# Altium schematic sheet Fonte.SchDoc(Fonte)
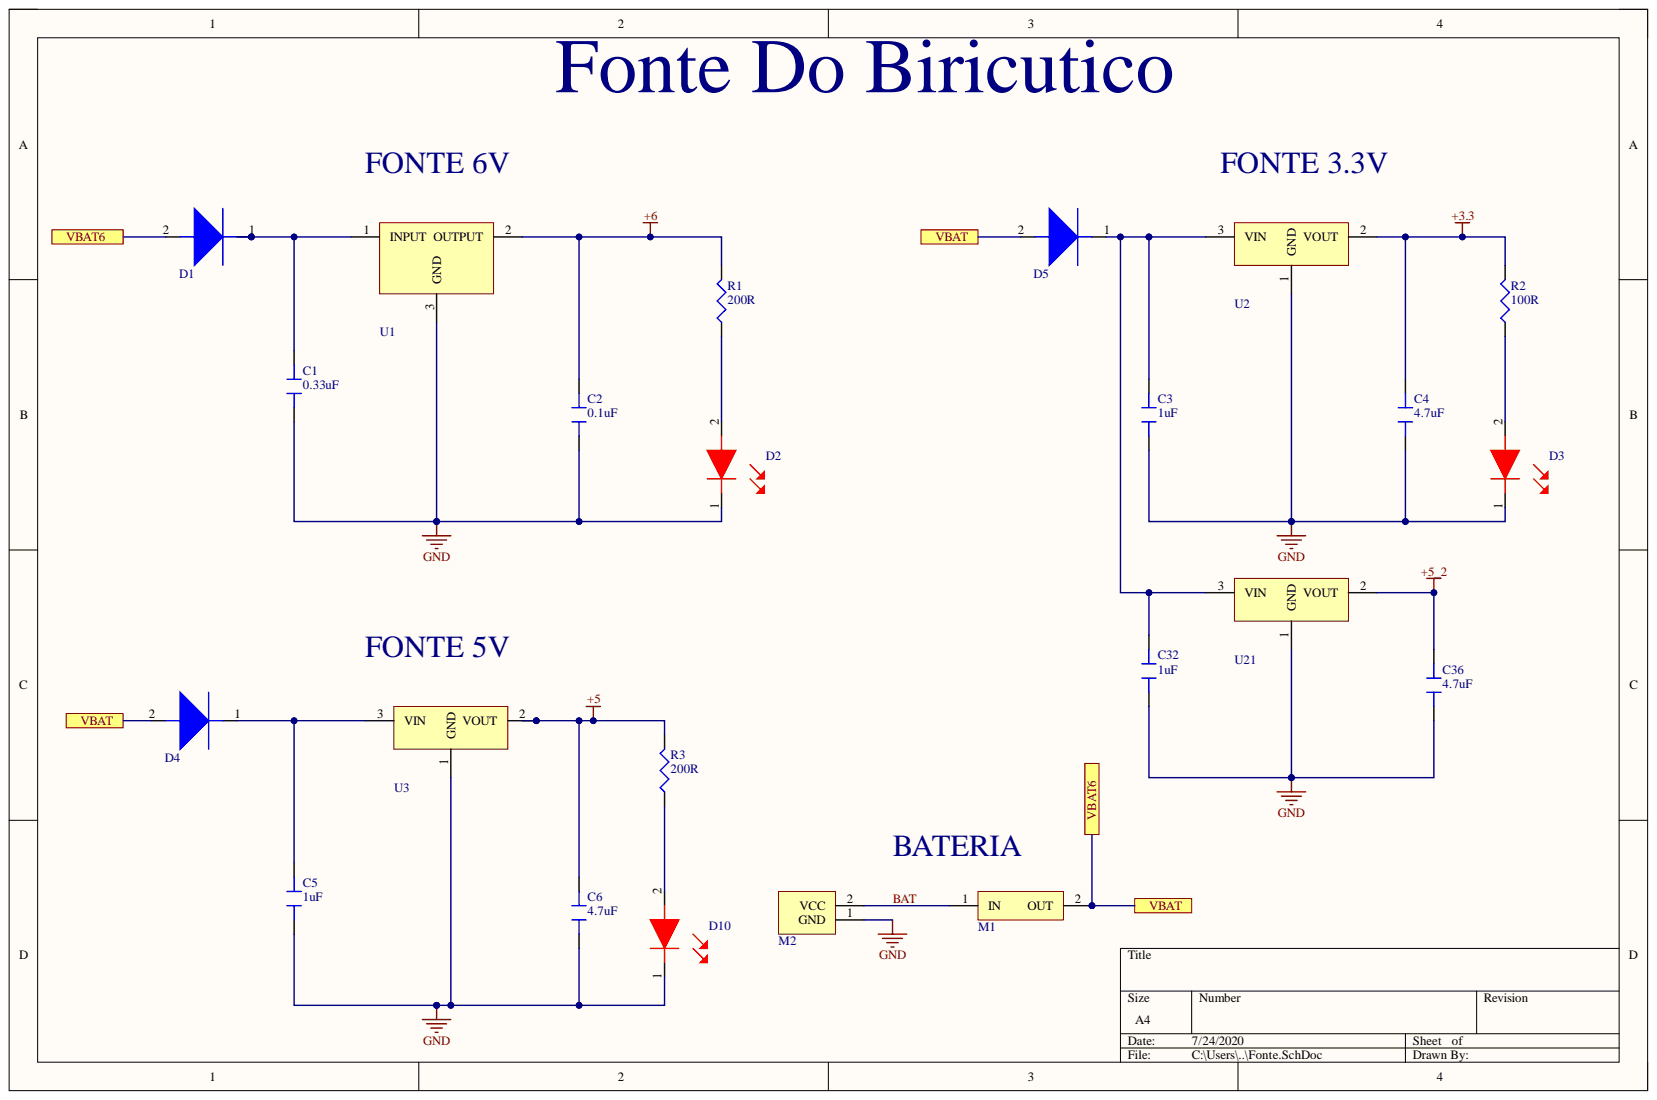
[diagram p0: Fonte.SchDoc]

## Parts
C1  0.33uF  [CAPACITOR 0805]
C2  0.1uF  [CAPACITOR 0805]
C3  1uF  [CAPACITOR 0805]
C4  4.7uF  [CAPACITOR 0805]
C5  1uF  [CAPACITOR 0805]
C6  4.7uF  [CAPACITOR 0805]
C32  1uF  [CAPACITOR 0805]
C36  4.7uF  [CAPACITOR 0805]
D1  Schottky Diode  [SODFL3516X85N]  (value not drawn)
D2  Standard LEDs - SMD  [Standard LEDs - SMD]  (value not drawn)
D3  Standard LEDs - SMD  [Standard LEDs - SMD]  (value not drawn)
D4  Schottky Diode  [SODFL3516X85N]  (value not drawn)
D5  Schottky Diode  [SODFL3516X85N]  (value not drawn)
D10  Standard LEDs - SMD  [Standard LEDs - SMD]  (value not drawn)
M1  Slide Switches  [Power_Switch]  (value not drawn)
M2  Headers (1x2)  [BATT]  (value not drawn)
R1  200R  [6-0805_M]
R2  100R  [0603]
R3  200R  [6-0805_M]
U1  Voltage Regulators 6V  [Voltage Regulators 6V]  (value not drawn)
U2  Voltage Regulators 3.3V  [SOT230P700X180-4N]  (value not drawn)
U3  Voltage Regulators 5V  [SOT230P700X180-4N]  (value not drawn)
U21  Voltage Regulators 5V  [SOT230P700X180-4N]  (value not drawn)

## Ports
VBAT, VBAT6

## Nets
+3.3: C4.2 R2.2 U2.2
+5: C6.2 R3.2 U3.2
+5_2: C36.2 U21.2
+6: C2.1 R1.2 U1.2
GND: C1.1 C2.2 C3.1 C4.1 C5.1 C6.1 C32.1 C36.1 D2.1 D3.1 D10.1 M2.1 U1.3 U2.1 U3.1 U21.1
BAT: M1.1 M2.2
VBAT: D1.2 D4.2 D5.2 M1.2 ; port VBAT, port VBAT6
NetC1_2: C1.2 D1.1 U1.1
NetC3_2: C3.2 C32.2 D5.1 U2.3 U21.3
NetC5_2: C5.2 D4.1 U3.3
NetD2_2: D2.2 R1.1
NetD3_2: D3.2 R2.1
NetD10_2: D10.2 R3.1

## Library data of the parts
C1 = Capacitor 0.33uF 0805: Multilayer Ceramic Capacitors MLCC - SMD/SMT 0805 0.33uF 16volts X7R 5%; Manufacturer: Vishay Vitramon; Manufacturer Part Number: VJ0805Y334JXJRW1BC; Capacitance: 330nF; Dielectric Material: Tantalum; Voltage Rating (DC): 16V; Case Code (Imperial): 0805; Case Code (Metric): 2012; Case/Package: 0805; Category: Multilayer Ceramic Capacitors MLCC - SMD/SMT; Component Kind: Standard; ComponentLink1Description: http://www.mouser.com/ds/2/427/vjw1bcbascomseries-223529.pdf?src-supplier=Mouser; ComponentLink1URL: http://www.mouser.com/ds/2/427/vjw1bcbascomseries-223529.pdf?src-supplier=Mouser; ComponentLink2Description: http://www.vishay.com/docs/28548/vjw1bcbascomseries.pdf?src-supplier=Avnet; ComponentLink2URL: http://www.vishay.com/docs/28548/vjw1bcbascomseries.pdf?src-supplier=Avnet; Depth: 1.25mm; Dielectric: X7R; Height: 1.45mm; Lead Free: Lead Free; Length: 2mm; Max Operating Temperature: 125°C; Min Operating Temperature: -55°C; Mount: Surface Mount; Package Quantity: 10000; Packaging: Tape and Reel; Pricing 1: 1=0.52, 10=0.52, 50=0.424, 100=0.308, 1000=0.135, 10000=0.075 (USD); Stock 1: 9308; Supplier 1: Mouser; Supplier Part Number 1: 77-VJ0805Y334JXJRBC; Termination: SMD/SMT; Tolerance: 5%; Weight: 0.000194oz; Width: 1.25mm; Library Name: BiricuticoBonito.SchLib
C2 = Capacitor  0.1uF 0805: Multilayer Ceramic Capacitors MLCC - SMD/SMT .1uF 50volts 10%; Manufacturer: Vishay Vitramon; Manufacturer Part Number: VJ0805Y104KXAAT; Capacitance: 100nF; Dielectric: X7R; Voltage: 50V; Case Code (Imperial): 0805; Case Code (Metric): 2012; Case/Package: 0805; Category: Multilayer Ceramic Capacitors MLCC - SMD/SMT; Component Kind: Standard; ComponentLink1Description: http://www.mouser.com/ds/2/427/vjcommercialseries-222796.pdf?src-supplier=Mouser; ComponentLink1URL: http://www.mouser.com/ds/2/427/vjcommercialseries-222796.pdf?src-supplier=Mouser; ComponentLink2Description: http://www.vishay.com/docs/45199/vjcommercialseries.pdf?src-supplier=Digi-Key; ComponentLink2URL: http://www.vishay.com/docs/45199/vjcommercialseries.pdf?src-supplier=Digi-Key; ComponentLink3Description: http://www.vishay.com/docs/45199/vjcommercialseries.pdf?src-supplier=Future+Electronics; ComponentLink3URL: http://www.vishay.com/docs/45199/vjcommercialseries.pdf?src-supplier=Future+Electronics; Depth: 1.25mm; Height: 1.45mm; Lead Free: Lead Free; Length: 2mm; Max Operating Temperature: 125°C; Min Operating Temperature: -55°C; Mount: Surface Mount; Package Quantity: 1; Packaging: Tape and Reel; Pricing 1: 1=0.2, 10=0.2, 50=0.162, 100=0.108, 500=0.095, 1000=0.084, 3000=0.072, 6000=0.069, 9000=0.065, 10000=0.065 (USD); Radiation Hardening: No; REACH SVHC: Unknown; Stock 1: 207342; Supplier 1: Mouser; Supplier Part Number 1: 77-VJ0805Y104KXAAT; Termination: SMD/SMT; Thickness: 0.057inch; Tolerance: 10%; Voltage Rating: 50V; Voltage Rating (DC): 50V; Weight: 0.000194oz; Width: 0.049inch; Library Name: BiricuticoBonito.SchLib
C3 C5 C32 = Capacitor 1uF 0805: Cap Ceramic 1uF 25V X7R 10% SMD 0805 125C Blister T/R; Manufacturer: Vishay; Manufacturer Part Number: VJ0805Y105KXXTW1BC; Dielectric Material: Tantalum; Capacitance: 1uF; Case/Package: 0805; Category: Multilayer Ceramic Capacitors MLCC - SMD/SMT; Component Kind: Standard; ComponentLink1Description: http://www.vishay.com/docs/28548/vjw1bcbascomseries.pdf?src-supplier=Avnet+Europe; ComponentLink1URL: http://www.vishay.com/docs/28548/vjw1bcbascomseries.pdf?src-supplier=Avnet+Europe; Depth: 1.25mm; Dielectric: X7R; Height: 1.25mm; Lead Free: Lead Free; Length: 2mm; Max Operating Temperature: 125°C; Min Operating Temperature: -55°C; Mount: Surface Mount; Packaging: Tape and Reel; Pricing 1: 1=0.11, 10=0.11, 50=0.11, 100=0.055, 1000=0.038, 10000=0.038 (USD); Radiation Hardening: No; Stock 1: 40011; Supplier 1: Mouser; Supplier Part Number 1: 77-VJ805Y105KXXTW1BC; Termination: Wraparound; Tolerance: 10%; Voltage Rating (DC): 25V; Library Name: BiricuticoBonito.SchLib
C4 C6 C36 = Capacitor 4.7uF 0805: MURATA - GRM21BR61H475KE51L - CAP, 4.7µF, 50V, 10%, X5R, 0805; Material: Ceramic; Capacitance: 4.7uF; Case Code (Imperial): 0805; Case Code (Metric): 2012; Case/Package: 0805; Category: Multilayer Ceramic Capacitors MLCC - SMD/SMT; Component Kind: Standard; ComponentLink1Description: http://components.arrow.com/part/dataview/61084395S454511N2606?src-supplier=Arrow; ComponentLink1URL: http://components.arrow.com/part/dataview/61084395S454511N2606?src-supplier=Arrow; ComponentLink2Description: http://components.arrow.com/part/dataview/0323_07281654?src-supplier=Arrow+Europe; ComponentLink2URL: http://components.arrow.com/part/dataview/0323_07281654?src-supplier=Arrow+Europe; ComponentLink3Description: https://search.murata.co.jp/Ceramy/image/img/A01X/G101/ENG/GRM21BR61H475KE51-01.pdf?src-supplier=Digi-Key; ComponentLink3URL: https://search.murata.co.jp/Ceramy/image/img/A01X/G101/ENG/GRM21BR61H475KE51-01.pdf?src-supplier=Digi-Key; ComponentLink4Description: http://search.murata.co.jp/Ceramy/image/img/A01X/G101/ENG/GRM21BR61H475KE51-01.pdf?src-supplier=Digi-Key; ComponentLink4URL: http://search.murata.co.jp/Ceramy/image/img/A01X/G101/ENG/GRM21BR61H475KE51-01.pdf?src-supplier=Digi-Key; ComponentLink5Description: http://www.farnell.com/datasheets/2079186.pdf?src-supplier=Farnell; ComponentLink5URL: http://www.farnell.com/datasheets/2079186.pdf?src-supplier=Farnell; ComponentLink6Description: http://www.farnell.com/datasheets/2079186.pdf?src-supplier=Element14; ComponentLink6URL: http://www.farnell.com/datasheets/2079186.pdf?src-supplier=Element14; ComponentLink7Description: http://www.farnell.com/datasheets/2079186.pdf?src-supplier=Newark; ComponentLink7URL: http://www.farnell.com/datasheets/2079186.pdf?src-supplier=Newark; Depth: 1.25mm; Dielectric: X5R; Height: 1.25mm; Lead Free: Lead Free; Length: 2mm; Manufacturer: Murata; Manufacturer Part Number: GRM21BR61H475KE51L; Max Operating Temperature: 85°C; Min Operating Temperature: -55°C; Mount: Surface Mount; Packaging: Tape and Reel; Pricing 1: 1=0.37, 10=0.256, 50=0.256, 100=0.153, 500=0.115, 1000=0.097, 3000=0.08, 6000=0.075, 9000=0.071, 10000=0.071 (USD); REACH SVHC: No SVHC; Stock 1: 243744; Supplier 1: Mouser; Supplier Part Number 1: 81-GRM21BR61H475KE1L; Thickness: 0.055inch; Tolerance: 10%; Voltage Rating: 50V; Voltage Rating (DC): 50V; Width: 0.049inch; Library Name: BiricuticoBonito.SchLib
D1 D4 D5 = Schottky Diode: DIODE SCHOTTKY 40V 3A MINI2; Manufacturer: Panasonic; Manufacturer Part Number: DB2W40300L; Element Configuration: Single; Max Forward Surge Current (Ifsm): 30A; Max Reverse Leakage Current: 250uA; Recovery Time: 15ns; Average Rectified Current: 3A; Category: Schottky Diodes & Rectifiers; Component Kind: Standard; ComponentLink1Description: http://www.mouser.com/ds/2/315/DB2W403_BED-276390.pdf?src-supplier=Mouser; ComponentLink1URL: http://www.mouser.com/ds/2/315/DB2W403_BED-276390.pdf?src-supplier=Mouser; Forward Current: 3A; Height: 0.8mm; Lead Free: Lead Free; Length: 2.6mm; Max Operating Temperature: 150°C; Max Repetitive Reverse Voltage (Vrrm): 40V; Max Reverse Voltage (DC): 40V; Max Surge Current: 30A; Min Operating Temperature: -55°C; Mount: Surface Mount; Number of Pins: 2; Package Quantity: 3000; Packaging: Tape and Reel; Peak Reverse Current: 250uA; Pricing 1: 1=0.6, 10=0.421, 50=0.421, 100=0.277, 500=0.164, 1000=0.126, 3000=0.104, 9000=0.095, 10000=0.095, 24000=0.089, 45000=0.08 (USD); Reverse Recovery Time: 15ns; Stock 1: 145800; Supplier 1: Mouser; Supplier Part Number 1: 667-DB2W40300L; Width: 1.6mm; Library Name: BiricuticoBonito.SchLib
D2 D3 D10 = Standard LEDs - SMD: WURTH ELEKTRONIK - 150060RS75000 - LED, 0603, RED, 250MCD, 625NM; Manufacturer: Wurth Electronics; Forward Current: 30mA; Forward Voltage: 2V; Lens Style: Clear,Rectangular; Test Current: 20mA; Viewing Angle: 140°; Case Code (Imperial): 0603; Case Code (Metric): 1608; Case/Package: 0603; Category: Standard LEDs - SMD; Color: Red; Component Kind: Standard; ComponentLink1Description: https://katalog.we-online.de/led/datasheet/150060RS75000.pdf?src-supplier=Digi-Key; ComponentLink1URL: https://katalog.we-online.de/led/datasheet/150060RS75000.pdf?src-supplier=Digi-Key; ComponentLink2Description: http://www.produktinfo.conrad.com/datenblaetter/750000-774999/759428-da-01-en-CHIPLED_0603_W_KL_ROT_150060RS75000.pdf?src-supplier=Conrad; ComponentLink2URL: http://www.produktinfo.conrad.com/datenblaetter/750000-774999/759428-da-01-en-CHIPLED_0603_W_KL_ROT_150060RS75000.pdf?src-supplier=Conrad; Dominant Wavelength: 625nm; Height: 0.7mm; Length: 1.6mm; Luminous Intensity: 250mcd; Manufacturer Part Number: 150060RS75000; Max Operating Temperature: 85°C; Min Operating Temperature: -40°C; Mount: Surface Mount; Package Quantity: 1; Packaging: Cut Tape; Pricing 1: 1=0.14, 10=0.138, 50=0.129, 100=0.11, 1000=0.095, 10000=0.095 (USD); REACH SVHC: Unknown; Stock 1: 77279; Supplier 1: Mouser; Supplier Part Number 1: 710-150060RS75000; Wavelength: 625nm; Width: 0.8mm; Library Name: BiricuticoBonito.SchLib
M1 = Slide Switches: 46202LRX-; Manufacturer: Switchcraft; Actuator Length: 8.74mm; Actuator Material: Thermoplastic; Actuator Type: Standard; Contact Current Rating: 3A; Contact Style: Non-Shorting; Max Voltage Rating (AC): 125V; Switch Function: On-Off; Switch Travel: 4.78mm; Throw Configuration: SPST; Category: Slide Switches; Component Kind: Standard; ComponentLink1Description: https://www.alliedelec.com/m/d/b871a11ddd3a58540a403f8c1668b2ef.pdf?src-supplier=Allied+Electronics; ComponentLink1URL: https://www.alliedelec.com/m/d/b871a11ddd3a58540a403f8c1668b2ef.pdf?src-supplier=Allied+Electronics; ComponentLink2Description: http://uk.rs-online.com/webdocs/141a/0900766b8141acc7.pdf?src-supplier=RSComponents; ComponentLink2URL: http://uk.rs-online.com/webdocs/141a/0900766b8141acc7.pdf?src-supplier=RSComponents; ComponentLink3Description: http://www.switchcraft.com/Drawings/46200LRX_SERIES_CD.pdf?src-supplier=Digi-Key; ComponentLink3URL: http://www.switchcraft.com/Drawings/46200LRX_SERIES_CD.pdf?src-supplier=Digi-Key; ComponentLink4Description: http://www.switchcraft.com/Drawings/46200LRX%20SERIES_CD.pdf?src-supplier=Mouser; ComponentLink4URL: http://www.switchcraft.com/Drawings/46200LRX%20SERIES_CD.pdf?src-supplier=Mouser; ComponentLink5Description: http://www.farnell.com/datasheets/814601.pdf?src-supplier=Farnell; ComponentLink5URL: http://www.farnell.com/datasheets/814601.pdf?src-supplier=Farnell; ComponentLink6Description: http://components.arrow.com/part/dataview/42506918S9181833N6102?src-supplier=Arrow; ComponentLink6URL: http://components.arrow.com/part/dataview/42506918S9181833N6102?src-supplier=Arrow; ComponentLink7Description: http://www.farnell.com/datasheets/814601.pdf?src-supplier=Element14; ComponentLink7URL: http://www.farnell.com/datasheets/814601.pdf?src-supplier=Element14; Contact Material: Copper Alloy,Brass; Contact Plating: Silver; Current Rating: 3A; Depth: 13.46mm; Housing Material: Steel; Lead Free: Lead Free; Length: 35.05mm; Manufacturer Part Number: 46202LRX; Max Current Rating: 3A; Max Operating Temperature: 70°C; Max Voltage Rating (DC): 125V; Min Operating Temperature: -20°C; Mount: Panel; Orientation: Vertical; Package Quantity: 1; Packaging: Bulk; Pricing 1: 1=1.31, 10=1.27, 25=1.15, 50=1.02, 100=0.897, 1000=0.897, 10000=0.897 (USD); REACH SVHC: Unknown; Stock 1: 374; Supplier 1: Mouser; Supplier Part Number 1: 502-46202LRX; Termination: Solder; Voltage Rating: 125V; Voltage Rating (AC): 125V; Voltage Rating (DC): 125V; Width: 13.5mm; Library Name: BiricuticoBonito.SchLib
M2 = Headers (1x2): Headers & Wire Housings HDR STRT SINGLE 2P; Manufacturer: Molex; Manufacturer Part Number: 90136-1202; Contact Resistance: 20mR; Current Rating: 3A; Gender: Male; Glow Wire Compliant: Non-compliant; Insulation Resistance: 5GR; Max Voltage Rating (DC): 350.35kV; Number of Circuits: 2; Pitch: 2.54mm; Voltage Rating: 350V; Category: Headers & Wire Housings; Color: Black; Component Kind: Standard; ComponentLink1Description: http://www.molex.com/webdocs/datasheets/pdf/en-us/0901361202_PCB_HEADERS.pdf?src-supplier=Avnet+Europe; ComponentLink1URL: http://www.molex.com/webdocs/datasheets/pdf/en-us/0901361202_PCB_HEADERS.pdf?src-supplier=Avnet+Europe; ComponentLink2Description: http://www.mouser.com/ds/2/276/0901361202_PCB_HEADERS-176812.pdf?src-supplier=Mouser; ComponentLink2URL: http://www.mouser.com/ds/2/276/0901361202_PCB_HEADERS-176812.pdf?src-supplier=Mouser; ComponentLink3Description: http://www.molex.com/webdocs/datasheets/pdf/en-us/0901361202_PCB_HEADERS.pdf?src-supplier=Avnet; ComponentLink3URL: http://www.molex.com/webdocs/datasheets/pdf/en-us/0901361202_PCB_HEADERS.pdf?src-supplier=Avnet; ComponentLink4Description: https://www.distrelec.biz/Web/Downloads/_d/wg/cgrid_III_90136_eng_dwg.pdf?mime=application%2Fpdf&src-supplier=Distrelec; ComponentLink4URL: https://www.distrelec.biz/Web/Downloads/_d/wg/cgrid_III_90136_eng_dwg.pdf?mime=application%2Fpdf&src-supplier=Distrelec; ComponentLink5Description: http://uk.rs-online.com/webdocs/1362/0900766b81362c51.pdf?src-supplier=RSComponents; ComponentLink5URL: http://uk.rs-online.com/webdocs/1362/0900766b81362c51.pdf?src-supplier=RSComponents; ComponentLink6Description: http://www.onlinecomponents.com/productFiles/mf-MOL/248400901361202_pcb_headers.pdf?src-supplier=Onlinecomponents.com; ComponentLink6URL: http://www.onlinecomponents.com/productFiles/mf-MOL/248400901361202_pcb_headers.pdf?src-supplier=Onlinecomponents.com; Contact Material: Brass,Copper Alloy; Contact Plating: Gold; Flammability Rating: UL94 V-0; Housing Color: Black; Housing Material: Polyester; Lead Free: Lead Free; Lead Pitch: 2.54mm; Max Operating Temperature: 125°C; Min Operating Temperature: -55°C; Mount: Through Hole; Number of Contacts: 2; Number of Poles: 2; Number of Positions: 2; Number of Rows: 1; Orientation: Vertical; Package Quantity: 3040; Packaging: Tray; Pricing 1: 1=1.07, 10=0.89, 50=0.89, 100=0.776, 500=0.677, 1000=0.613, 2500=0.536, 10000=0.492, 25000=0.479, 50000=0.467 (USD); Radiation Hardening: No; REACH SVHC: Unknown; Stock 1: 2623; Supplier 1: Mouser; Supplier Part Number 1: 538-90136-1202; Termination: Through Hole; Voltage Rating (AC): 350V; Voltage Rating (DC): 350V; Working Voltage: 350V; Library Name: BiricuticoBonito.SchLib
R1 R3 = Resistor 200 0805: RES SMD 200 OHM 1% 1/8W 0805; Manufacturer: Vishay; Manufacturer Part Number: CRCW0805200RFKEA; Max Power Dissipation: 125mW; Temperature Coefficient: 100ppm/°C; Voltage Rating: 150V; Case Code (Imperial): 0805; Case Code (Metric): 2012; Case/Package: 0805; Category: Thick Film Resistors - SMD; Component Kind: Standard; ComponentLink1Description: http://www.vishay.com/docs/20035/dcrcwe3.pdf?src-supplier=Digi-Key; ComponentLink1URL: http://www.vishay.com/docs/20035/dcrcwe3.pdf?src-supplier=Digi-Key; ComponentLink2Description: http://www.vishay.com/docs/20035/dcrcwe3.pdf?src-supplier=Avnet+Europe; ComponentLink2URL: http://www.vishay.com/docs/20035/dcrcwe3.pdf?src-supplier=Avnet+Europe; ComponentLink3Description: http://www.farnell.com/datasheets/2310790.pdf?src-supplier=Element14; ComponentLink3URL: http://www.farnell.com/datasheets/2310790.pdf?src-supplier=Element14; ComponentLink4Description: http://www.alliedelec.com/m/d/0c934d6939ccefa68dbe0b96d821c8cf.pdf?src-supplier=Allied+Electronics; ComponentLink4URL: http://www.alliedelec.com/m/d/0c934d6939ccefa68dbe0b96d821c8cf.pdf?src-supplier=Allied+Electronics; ComponentLink5Description: http://www.mouser.com/ds/2/427/dcrcwe3-109170.pdf?src-supplier=Mouser; ComponentLink5URL: http://www.mouser.com/ds/2/427/dcrcwe3-109170.pdf?src-supplier=Mouser; ComponentLink6Description: https://www.distrelec.biz/Web/Downloads/a_/en/flD-CRCW-e3_data_en.pdf?mime=application%2Fpdf&src-supplier=Distrelec; ComponentLink6URL: https://www.distrelec.biz/Web/Downloads/a_/en/flD-CRCW-e3_data_en.pdf?mime=application%2Fpdf&src-supplier=Distrelec; ComponentLink7Description: http://uk.rs-online.com/webdocs/13ec/0900766b813ecbf4.pdf?src-supplier=RSComponents; ComponentLink7URL: http://uk.rs-online.com/webdocs/13ec/0900766b813ecbf4.pdf?src-supplier=RSComponents; ComponentLink8Description: http://www.vishay.com/docs/20035/dcrcwe3.pdf?src-supplier=Avnet; ComponentLink8URL: http://www.vishay.com/docs/20035/dcrcwe3.pdf?src-supplier=Avnet; ComponentLink9Description: http://www.vishay.com/docs/20035/dcrcwe3.pdf?src-supplier=Future+Electronics; ComponentLink9URL: http://www.vishay.com/docs/20035/dcrcwe3.pdf?src-supplier=Future+Electronics; Composition: Thick Film; Contact Plating: Tin; Depth: 1.25mm; Features: Automotive AEC-Q200; Height: 0.45mm; Lead Free: Lead Free; Length: 2mm; Max Operating Temperature: 155°C; Military Standard: Not; Min Operating Temperature: -55°C; Mount: Surface Mount; Number of Pins: 2; Number of Terminations: 2; Package Quantity: 10; Packaging: Tape and Reel; Power Rating: 125mW; Pricing 1: 1=0.1, 10=0.066, 50=0.066, 100=0.047, 1000=0.027, 10000=0.015 (USD); Radiation Hardening: No; REACH SVHC: Unknown; Resistance: 200R; Stock 1: 44278; Supplier 1: Mouser; Supplier Part Number 1: 71-CRCW0805-200-E3; Termination: SMD/SMT; Tolerance: 1%; Voltage Rating (DC): 150V; Weight: 0.000194oz; Width: 0.049inch; Working Voltage: 150V; Library Name: BiricuticoBonito.SchLib
R2 = Resistor 100 0603: RES SMD 100 OHM 1% 1/10W 0603; Manufacturer: Vishay Intertechnology; Manufacturer Part Number: CRCW0603100RFKEA; Power Rating: 100mW; Temperature Coefficient: 100ppm/°C; Voltage Rating (DC): 75V; Case/Package: 0603; Category: Thick Film Resistors - SMD; Component Kind: Standard; Height: 450um; Lead Free: Lead Free; Length: 1.55mm; Number of Pins: 2; Packaging: Cut Tape; Pricing 1: 1=0.1, 10=0.037, 50=0.037, 100=0.026, 1000=0.006, 10000=0.005 (USD); REACH SVHC: No SVHC; Resistance: 100R; Stock 1: 221485; Supplier 1: Mouser; Supplier Part Number 1: 71-CRCW0603-100-E3; Tolerance: 1%; Width: 850um; Library Name: BiricuticoBonito.SchLib
U1 = Voltage Regulators 6V: IC REG LINEAR 6V 1.5A D2PAK; Manufacturer: STMicroelectronics; Manufacturer Part Number: L7806ABD2T-TR; Accuracy: 2%; Dropout Voltage: 2V; Max Input Voltage: 35V; Min Input Voltage: 8V; Number of Outputs: 1; Output Type: Fixed; Polarity: Positive; Power Supply Rejection Ratio (PSRR): 65dB; Quiescent Current: 6mA; Case/Package: TO-263; Category: Linear Voltage Regulators; Component Kind: Standard; ComponentLink1Description: http://www.st.com/content/ccc/resource/technical/document/datasheet/41/4f/b3/b0/12/d4/47/88/CD00000444.pdf/files/CD00000444.pdf/jcr:content/translations/en.CD00000444.pdf?src-supplier=Digi-Key; ComponentLink1URL: http://www.st.com/content/ccc/resource/technical/document/datasheet/41/4f/b3/b0/12/d4/47/88/CD00000444.pdf/files/CD00000444.pdf/jcr:content/translations/en.CD00000444.pdf?src-supplier=Digi-Key; ComponentLink2Description: http://www.st.com/web/en/resource/technical/document/datasheet/CD00000444.pdf?src-supplier=Avnet+Europe; ComponentLink2URL: http://www.st.com/web/en/resource/technical/document/datasheet/CD00000444.pdf?src-supplier=Avnet+Europe; ComponentLink3Description: http://www.st.com/web/en/resource/technical/document/datasheet/CD00000444.pdf?src-supplier=Future+Electronics; ComponentLink3URL: http://www.st.com/web/en/resource/technical/document/datasheet/CD00000444.pdf?src-supplier=Future+Electronics; ComponentLink4Description: http://www.mouser.com/ds/2/389/l78-974043.pdf?src-supplier=Mouser; ComponentLink4URL: http://www.mouser.com/ds/2/389/l78-974043.pdf?src-supplier=Mouser; ComponentLink5Description: http://www.st.com/web/en/resource/technical/document/datasheet/CD00000444.pdf?src-supplier=Avnet; ComponentLink5URL: http://www.st.com/web/en/resource/technical/document/datasheet/CD00000444.pdf?src-supplier=Avnet; Height: 4.6mm; Lead Free: Lead Free; Length: 10.4mm; Max Operating Temperature: 125°C; Max Output Current: 1.5A; Min Operating Temperature: -40°C; Min Output Voltage: 6V; Mount: Surface Mount; Number of Pins: 3; Number of Regulators: 1; Output Current: 1.5A; Output Voltage: 6V; Output Voltage Accuracy: 2%; Package Quantity: 1; Packaging: Tape and Reel; Pricing 1: 1=0.78, 10=0.651, 50=0.651, 100=0.42, 1000=0.336, 2000=0.284, 10000=0.274, 25000=0.263, 50000=0.259, 100000=0.253 (USD); Radiation Hardening: No; Stock 1: 2009; Supplier 1: Mouser; Supplier Part Number 1: 511-L7806ABD2T-TR; Width: 9.35mm; Library Name: BiricuticoBonito.SchLib
U2 = Voltage Regulators 3.3V: IC REG LINEAR 3.3V 1.2A SOT223; Manufacturer: STMicroelectronics; Manufacturer Part Number: LDL1117S33R; Dropout Voltage: 600mV; Max Input Voltage: 18V; Number of Regulators: 1; Output Configuration: Positive; Output Type: Fixed; Power Supply Rejection Ratio (PSRR): 65dB; Quiescent Current: 500uA; Case/Package: TO-261-4; Category: Linear Voltage Regulators; Component Kind: Standard; ComponentLink1Description: http://www.farnell.com/datasheets/2259188.pdf?src-supplier=Farnell; ComponentLink1URL: http://www.farnell.com/datasheets/2259188.pdf?src-supplier=Farnell; ComponentLink2Description: http://www.st.com/content/ccc/resource/technical/document/datasheet/group3/0e/5a/00/ca/10/1a/4f/a5/DM00366442/files/DM00366442.pdf/jcr:content/translations/en.DM00366442.pdf?src-supplier=Digi-Key; ComponentLink2URL: http://www.st.com/content/ccc/resource/technical/document/datasheet/group3/0e/5a/00/ca/10/1a/4f/a5/DM00366442/files/DM00366442.pdf/jcr:content/translations/en.DM00366442.pdf?src-supplier=Digi-Key; ComponentLink3Description: http://www.farnell.com/datasheets/2259188.pdf?src-supplier=Newark; ComponentLink3URL: http://www.farnell.com/datasheets/2259188.pdf?src-supplier=Newark; ComponentLink4Description: http://www.farnell.com/datasheets/2259188.pdf?src-supplier=Element14; ComponentLink4URL: http://www.farnell.com/datasheets/2259188.pdf?src-supplier=Element14; Max Operating Temperature: 125°C; Min Operating Temperature: -40°C; Min Output Voltage: 3.3V; Mount: Surface Mount; Output Current: 1.2A; Packaging: Tape and Reel; Pricing 1: 1=0.43, 10=0.332, 50=0.332, 100=0.18, 1000=0.135, 2500=0.116, 10000=0.109, 25000=0.1, 50000=0.096, 100000=0.093 (USD); Stock 1: 8562; Supplier 1: Mouser; Supplier Part Number 1: 511-LDL1117S33R; Library Name: BiricuticoBonito.SchLib
U3 U21 = Voltage Regulators 5V: IC REG LINEAR 5V 1.2A SOT223; Manufacturer: STMicroelectronics; Manufacturer Part Number: LDL1117S50R; Dropout Voltage: 600mV; Max Input Voltage: 18V; Number of Regulators: 1; Output Configuration: Positive; Output Type: Fixed; Power Supply Rejection Ratio (PSRR): 65dB; Quiescent Current: 500uA; Case/Package: TO-261-4; Category: Linear Voltage Regulators; Component Kind: Standard; ComponentLink1Description: http://www.st.com/content/ccc/resource/technical/document/datasheet/group3/0e/5a/00/ca/10/1a/4f/a5/DM00366442/files/DM00366442.pdf/jcr:content/translations/en.DM00366442.pdf?src-supplier=Digi-Key; ComponentLink1URL: http://www.st.com/content/ccc/resource/technical/document/datasheet/group3/0e/5a/00/ca/10/1a/4f/a5/DM00366442/files/DM00366442.pdf/jcr:content/translations/en.DM00366442.pdf?src-supplier=Digi-Key; ComponentLink2Description: http://www.farnell.com/datasheets/2259188.pdf?src-supplier=Farnell; ComponentLink2URL: http://www.farnell.com/datasheets/2259188.pdf?src-supplier=Farnell; Max Operating Temperature: 125°C; Min Operating Temperature: -40°C; Min Output Voltage: 5V; Mount: Surface Mount; Output Current: 1.2A; Packaging: Tape and Reel; Pricing 1: 1=0.44, 10=0.332, 50=0.332, 100=0.18, 1000=0.135, 2500=0.116, 10000=0.109 (USD); Stock 1: 9455; Supplier 1: Mouser; Supplier Part Number 1: 511-LDL1117S50R; Library Name: BiricuticoBonito.SchLib
